# Altium schematic sheet Sheet3.SchDoc
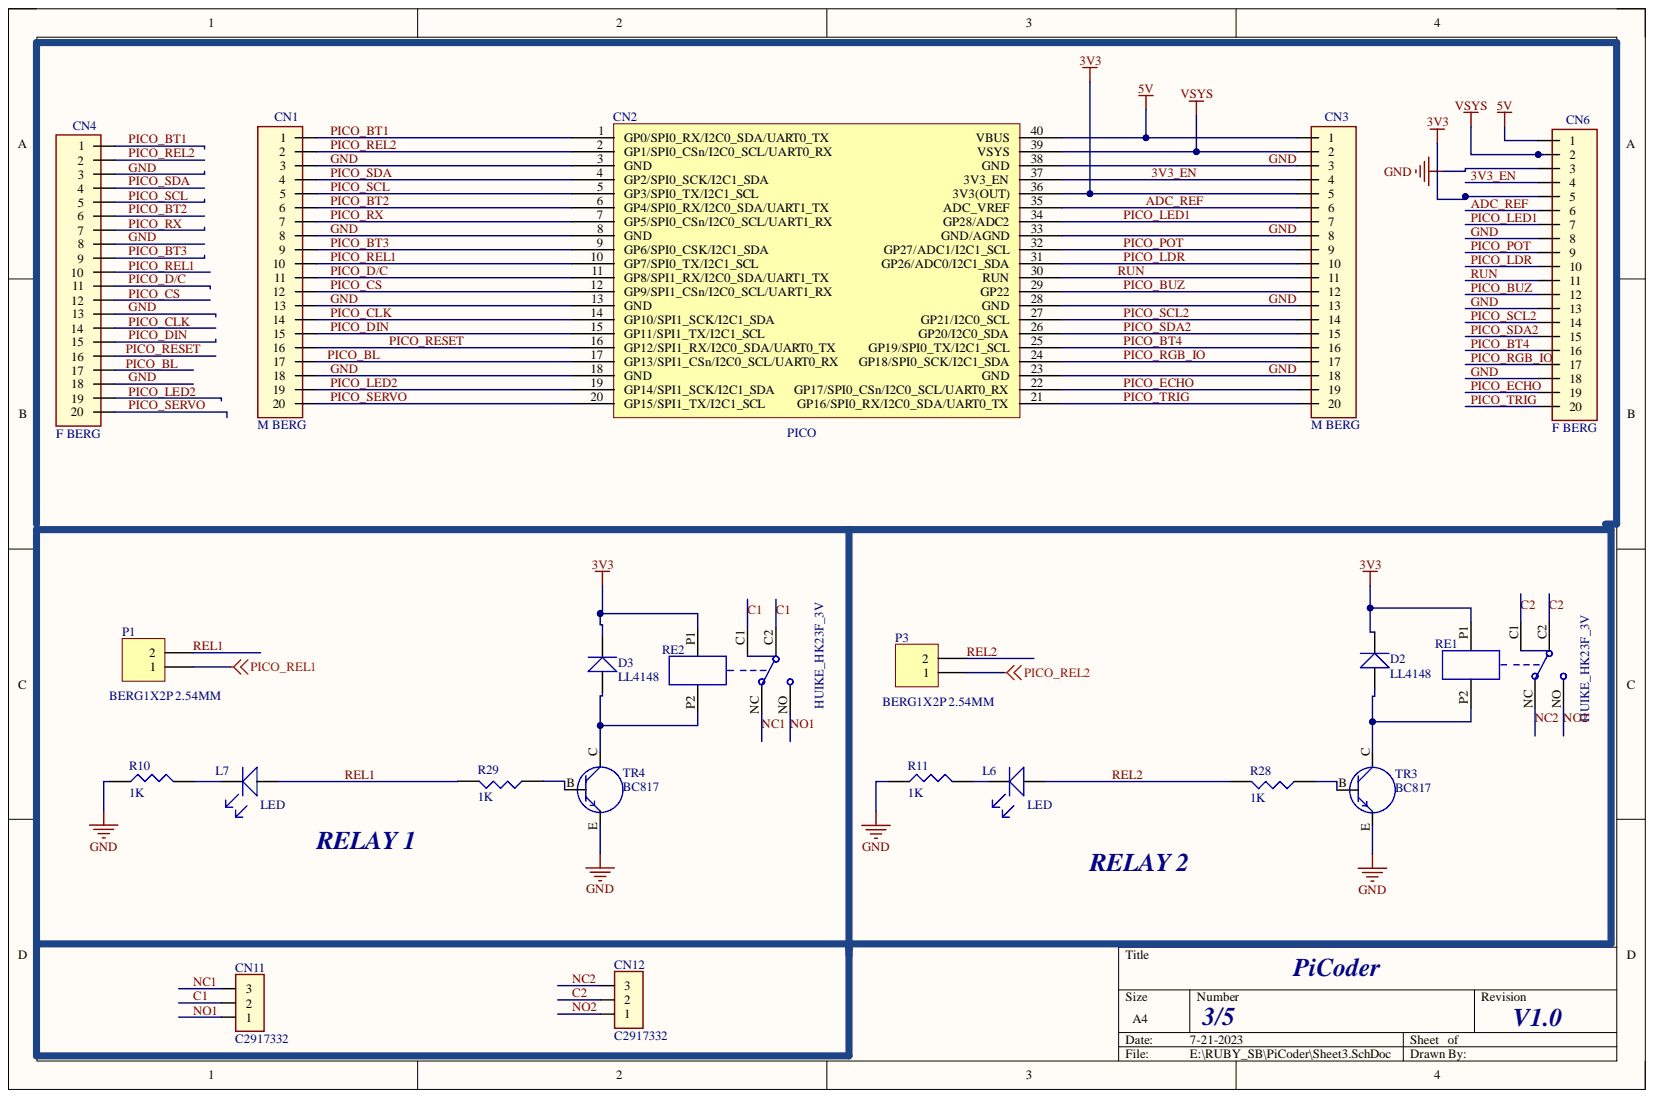
[diagram p0: Sheet3.SchDoc]

## Parts
CN1  M BERG  [BERG_F20P/2.54MM]
CN2  PICO  [PICO_TH]
CN3  M BERG  [BERG_F20P/2.54MM]
CN4  F BERG  [BERG_F20P/2.54MM]
CN6  F BERG  [BERG_F20P/2.54MM]
CN11  C2917332  [ST_SCREW 3.5MM 3P]
CN12  C2917332  [ST_SCREW 3.5MM 3P]
D2  LL4148  [LL4148_SOD80]
D3  LL4148  [LL4148_SOD80]
L6  LED  [LED_0603]
L7  LED  [LED_0603]
P1  BERG1X2P 2.54MM  [2P_2.54MM]
P3  BERG1X2P 2.54MM  [2P_2.54MM]
R10  1K  [R_0603]
R11  1K  [R_0603]
R28  1K  [R_0603]
R29  1K  [R_0603]
RE1  HUIKE_HK23F_3V  [3V3_RELAY]
RE2  HUIKE_HK23F_3V  [3V3_RELAY]
TR3  BC817  [SOT23]
TR4  BC817  [SOT23]

## Nets
3V3: CN2.36(3V3(OUT)) CN3.5 CN6.5 D2.K D3.K RE1.P1 RE2.P1
5V: CN2.40(VBUS) CN3.1 CN6.1
VSYS: CN2.39(VSYS) CN3.2 CN6.2
3V3_EN: CN2.37(3V3_EN) CN3.4 CN6.4
ADC_REF: CN2.35(ADC_VREF) CN3.6 CN6.6
C1: CN11.2 RE2.C1 RE2.C2
C2: CN12.2 RE1.C1 RE1.C2
GND: CN1.3 CN1.8 CN1.13 CN1.18 CN2.3(GND) CN2.8(GND) CN2.13(GND) CN2.18(GND) CN2.23(GND) CN2.28(GND) CN2.33(GND/AGND) CN2.38(GND) CN3.3 CN3.8 CN3.13 CN3.18 CN4.3 CN4.8 CN4.13 CN4.18 CN6.3 CN6.8 CN6.13 CN6.18 R10.1 R11.1 TR3.E TR4.E
NC1: CN11.3 RE2.NC
NC2: CN12.3 RE1.NC
NO1: CN11.1 RE2.NO
NO2: CN12.1 RE1.NO
PICO_BL: CN1.17 CN2.17(GP13/SPI1_CSn/I2C0_SCL/UART0_RX) CN4.17
PICO_BT1: CN1.1 CN2.1(GP0/SPI0_RX/I2C0_SDA/UART0_TX) CN4.1
PICO_BT2: CN1.6 CN2.6(GP4/SPI0_RX/I2C0_SDA/UART1_TX) CN4.6
PICO_BT3: CN1.9 CN2.9(GP6/SPI0_CSK/I2C1_SDA) CN4.9
PICO_BT4: CN2.25(GP19/SPI0_TX/I2C1_SCL) CN3.16 CN6.16
PICO_BUZ: CN2.29(GP22) CN3.12 CN6.12
PICO_CLK: CN1.14 CN2.14(GP10/SPI1_SCK/I2C1_SDA) CN4.14
PICO_CS: CN1.12 CN2.12(GP9/SPI1_CSn/I2C0_SCL/UART1_RX) CN4.12
PICO_D/C: CN1.11 CN2.11(GP8/SPI1_RX/I2C0_SDA/UART1_TX) CN4.11
PICO_DIN: CN1.15 CN2.15(GP11/SPI1_TX/I2C1_SCL) CN4.15
PICO_ECHO: CN2.22(GP17/SPI0_CSn/I2C0_SCL/UART0_RX) CN3.19 CN6.19
PICO_LDR: CN2.31(GP26/ADC0/I2C1_SDA) CN3.10 CN6.10
PICO_LED1: CN2.34(GP28/ADC2) CN3.7 CN6.7
PICO_LED2: CN1.19 CN2.19(GP14/SPI1_SCK/I2C1_SDA) CN4.19
PICO_POT: CN2.32(GP27/ADC1/I2C1_SCL) CN3.9 CN6.9
PICO_REL1: CN1.10 CN2.10(GP7/SPI0_TX/I2C1_SCL) CN4.10 P1.1
PICO_REL2: CN1.2 CN2.2(GP1/SPI0_CSn/I2C0_SCL/UART0_RX) CN4.2 P3.1
PICO_RESET: CN1.16 CN2.16(GP12/SPI1_RX/I2C0_SDA/UART0_TX) CN4.16
PICO_RGB_IO: CN2.24(GP18/SPI0_SCK/I2C1_SDA) CN3.17 CN6.17
PICO_RX: CN1.7 CN2.7(GP5/SPI0_CSn/I2C0_SCL/UART1_RX) CN4.7
PICO_SCL: CN1.5 CN2.5(GP3/SPI0_TX/I2C1_SCL) CN4.5
PICO_SCL2: CN2.27(GP21/I2C0_SCL) CN3.14 CN6.14
PICO_SDA: CN1.4 CN2.4(GP2/SPI0_SCK/I2C1_SDA) CN4.4
PICO_SDA2: CN2.26(GP20/I2C0_SDA) CN3.15 CN6.15
PICO_SERVO: CN1.20 CN2.20(GP15/SPI1_TX/I2C1_SCL) CN4.20
PICO_TRIG: CN2.21(GP16/SPI0_RX/I2C0_SDA/UART0_TX) CN3.20 CN6.20
REL1: L7.A P1.2 R29.2
REL2: L6.A P3.2 R28.2
RUN: CN2.30(RUN) CN3.11 CN6.11
NetD2_A: D2.A RE1.P2 TR3.C
NetD3_A: D3.A RE2.P2 TR4.C
NetL6_K: L6.K R11.2
NetL7_K: L7.K R10.2
NetR28_1: R28.1 TR3.B
NetR29_1: R29.1 TR4.B

## Library data of the parts
CN1 CN3 CN4 CN6 = CON20: Component Kind: Standard; Library Name: dxp_pasl_lib.IntLib
CN2 = CON40_PICO: Component Kind: Standard; Library Name: dxp_pasl_lib.IntLib
CN11 CN12 = CON3: Component Kind: Standard; Library Name: dxp_pasl_lib.IntLib
D2 D3 = DIODE: Component Kind: Standard; Library Name: dxp_pasl_lib.IntLib
P1 P3 = Header 2: Header, 2-Pin; Component Kind: Standard; LatestRevisionDate: 17-Jul-2002; LatestRevisionNote: Re-released for DXP Platform.; Library Name: Miscellaneous Connectors.IntLib; Signal Integrity: Connector; Publisher: Altium Limited
R10 R11 R28 R29 = RES: Component Kind: Standard; Library Name: dxp_pasl_lib.IntLib
TR3 TR4 = TRANSISTER_NPN: Component Kind: Standard; Library Name: dxp_pasl_lib.IntLib
L6 L7 = LED: Component Kind: Standard; Library Name: dxp_pasl_lib.IntLib
RE1 RE2 = HUIKE_HK23F_3V: Component Kind: Standard; Library Name: dxp_pasl_lib.IntLib
